# Altium schematic sheet Carambola2-Base.SchDoc
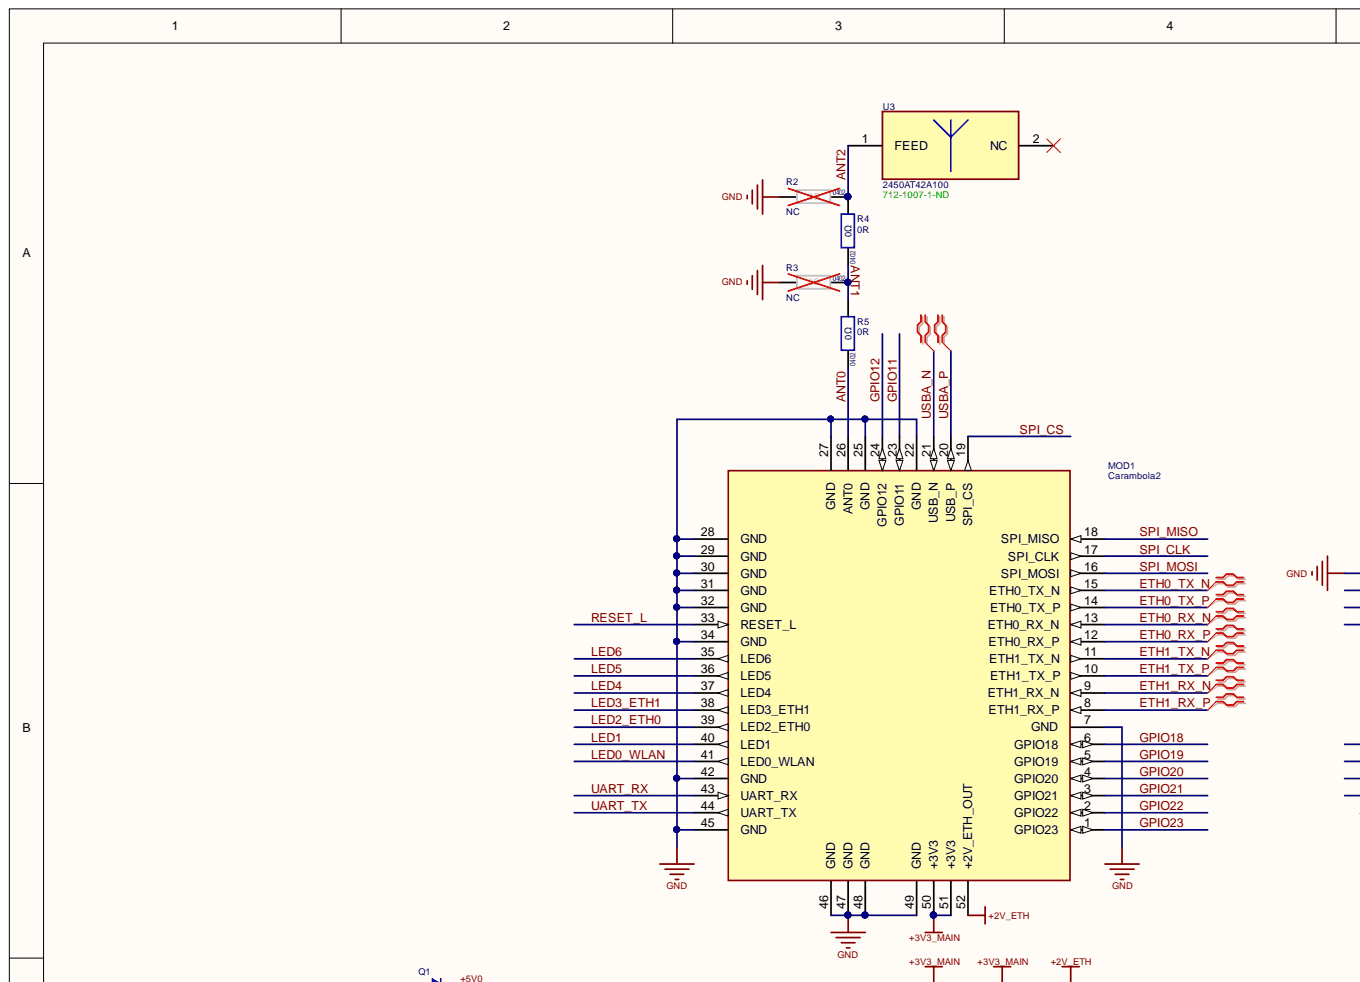
[diagram p0: Carambola2-Base.SchDoc, top left]
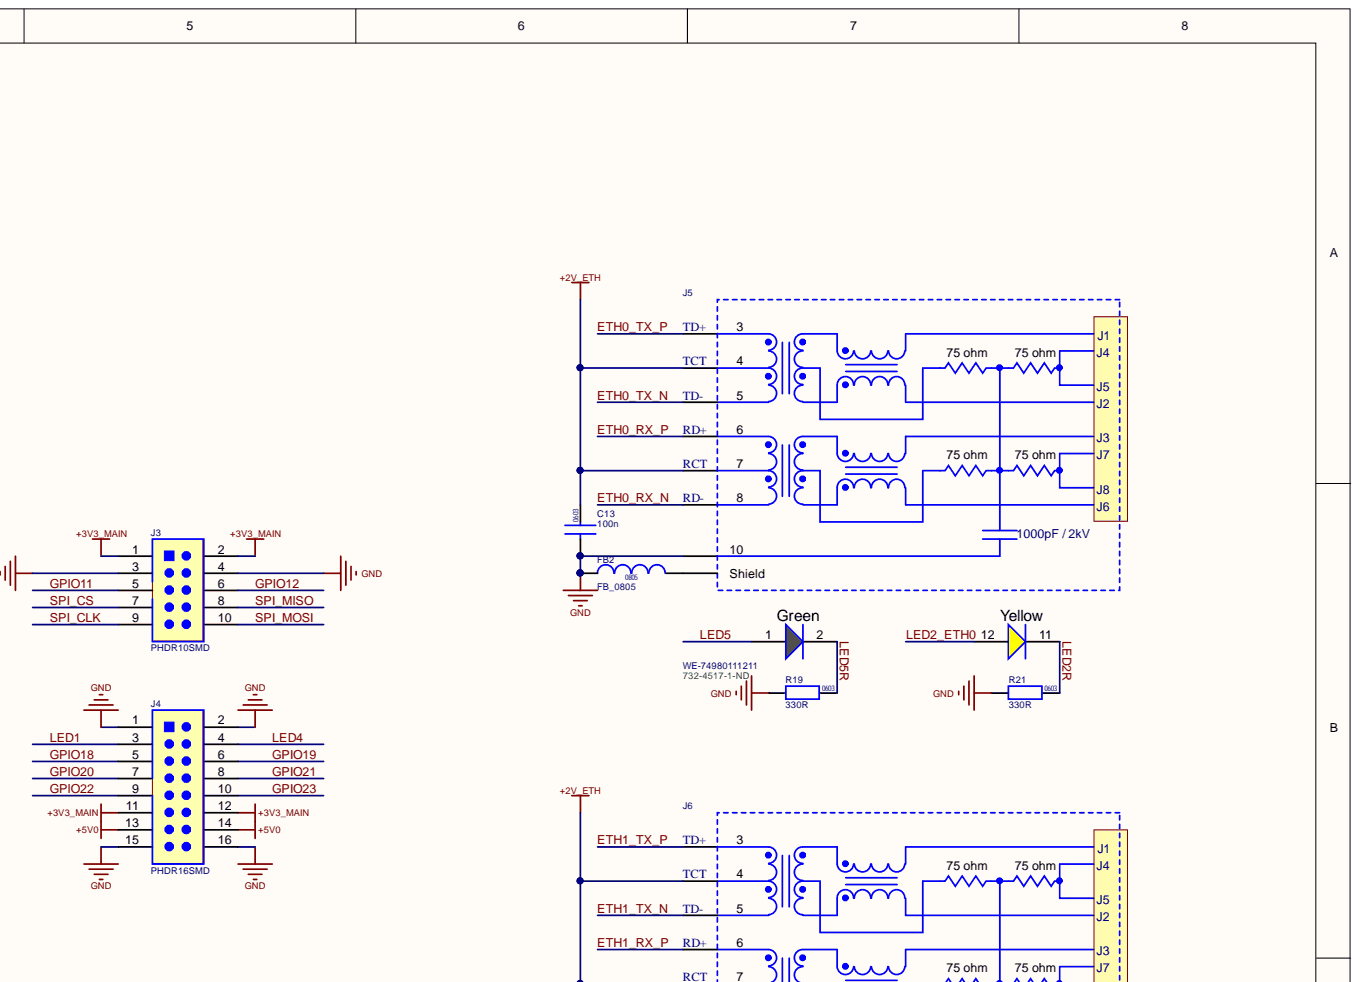
[diagram p1: Carambola2-Base.SchDoc, top right]
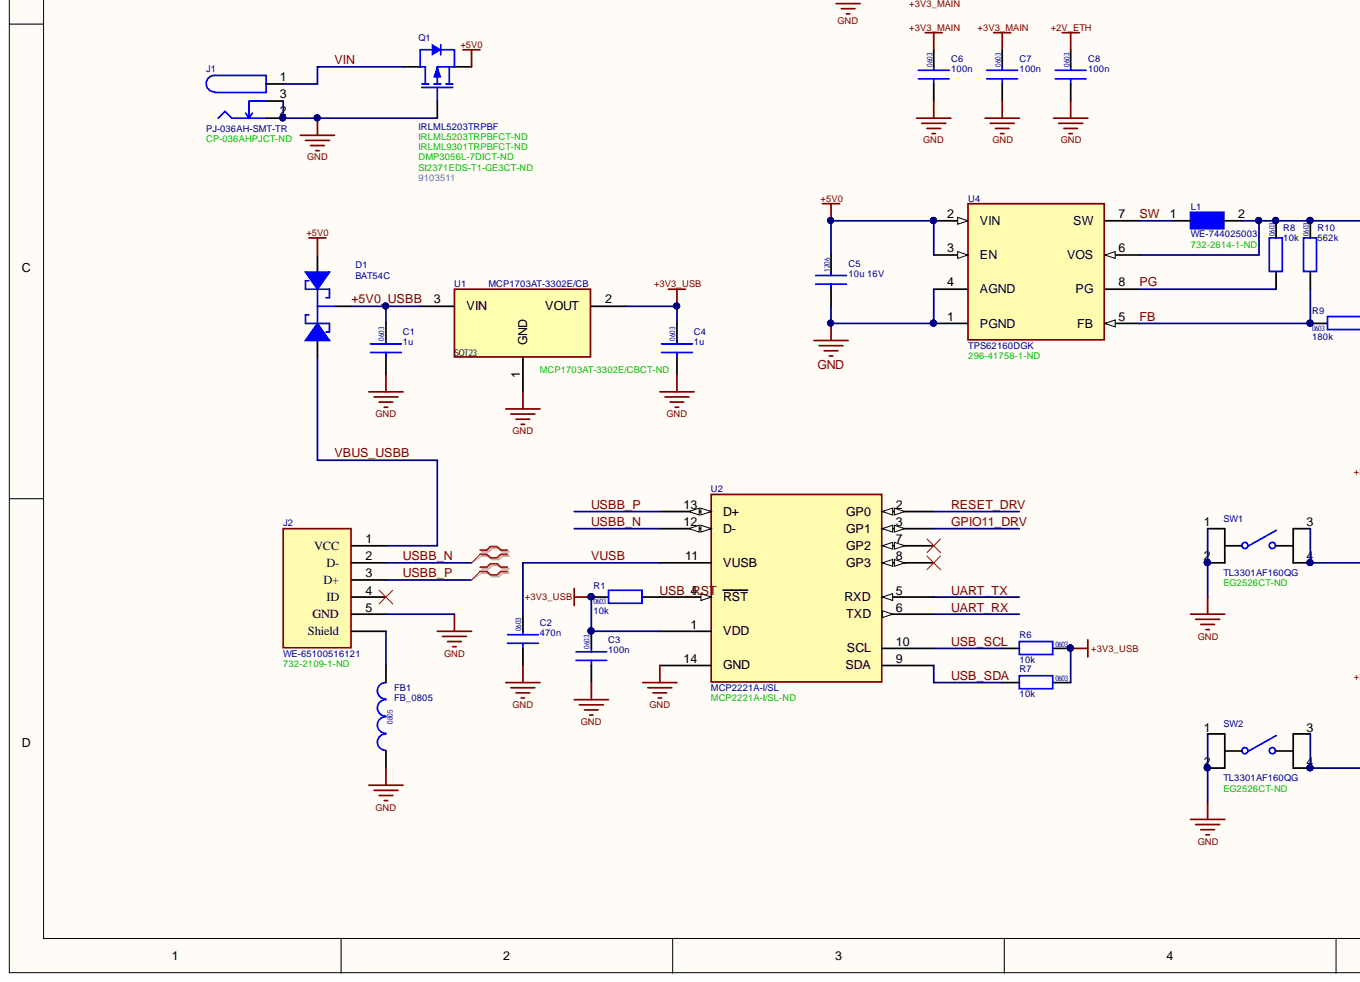
[diagram p2: Carambola2-Base.SchDoc, bottom left]
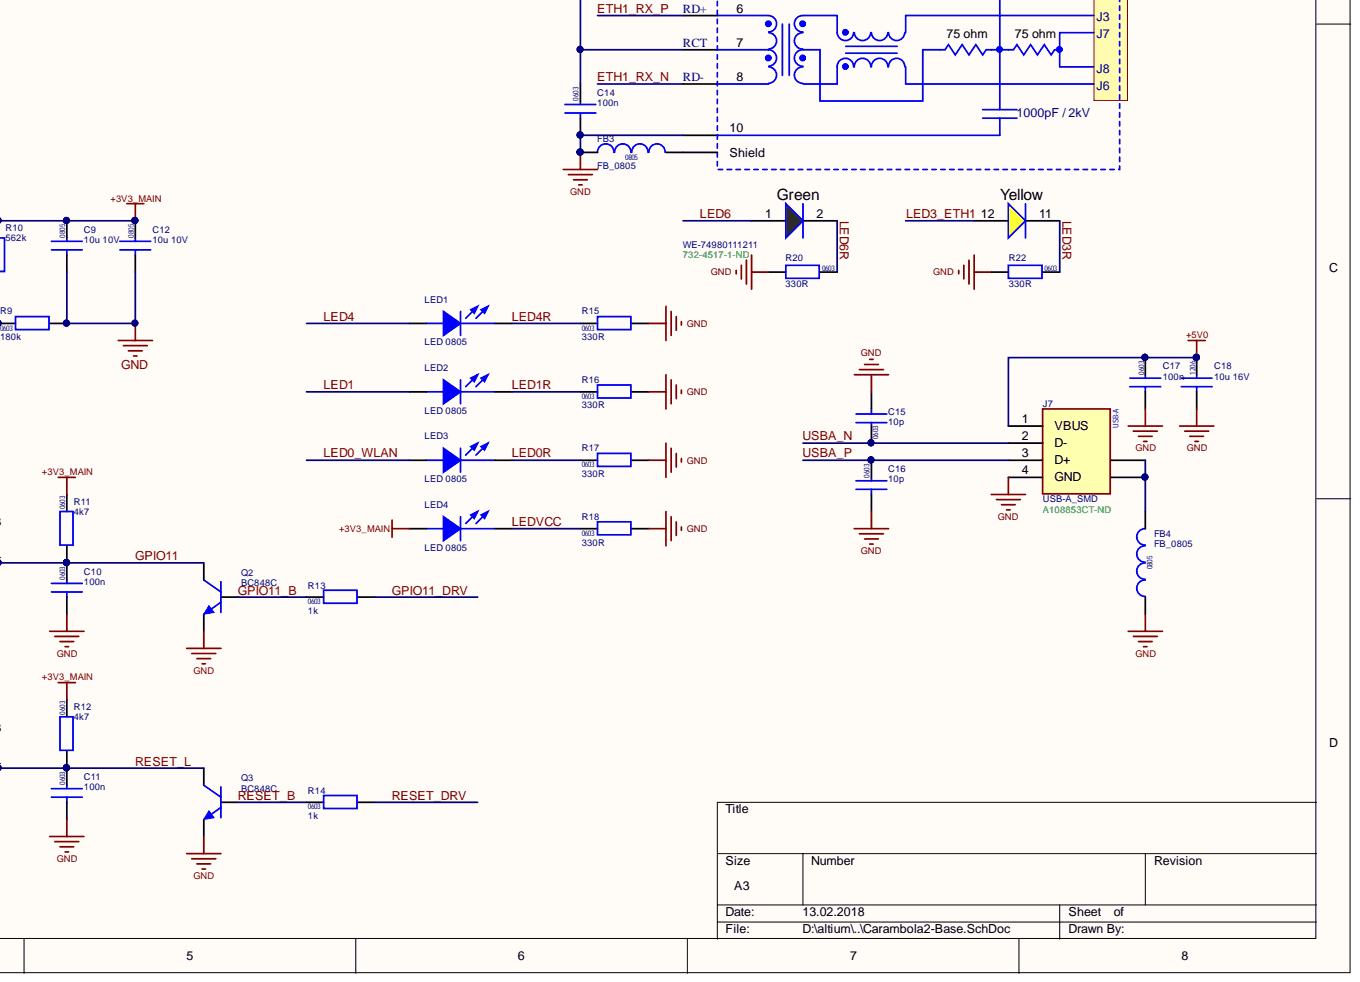
[diagram p3: Carambola2-Base.SchDoc, bottom right]

## Parts
C1  1u  [CAPC0603]
C2  470n  [CAPC0603]
C3  100n  [CAPC0603]
C4  1u  [CAPC0603]
C5  10u 16V  [CAPC1206]
C6  100n  [CAPC0603]
C7  100n  [CAPC0603]
C8  100n  [CAPC0603]
C9  10u 10V  [CAPC0805]
C10  100n  [CAPC0603]
C11  100n  [CAPC0603]
C12  10u 10V  [CAPC0805]
C13  100n  [CAPC0603]
C14  100n  [CAPC0603]
C15  10p  [CAPC0603]
C16  10p  [CAPC0603]
C17  100n  [CAPC0603]
C18  10u 16V  [CAPC1206]
D1  BAT54C  [SOT23-3]
FB1  FB_0805  [FB_0805]
FB2  FB_0805  [FB_0805]
FB3  FB_0805  [FB_0805]
FB4  FB_0805  [FB_0805]
J1  PJ-036AH-SMT-TR  Supplier Part Number 1: CP-036AHPJCT-ND  [PJ-036AH-SMT-TR]
J2  WE-65100516121  Supplier Part Number 1: 732-2109-1-ND  [65100516121]
J3  PHDR10SMD  [PHDR10SMD]
J4  PHDR16SMD  [PHDR16SMD]
J5  WE-74980111211  Supplier Part Number 1: 732-4517-1-ND  [74980111211]
J6  WE-74980111211  Supplier Part Number 1: 732-4517-1-ND  [74980111211]
J7  USB-A_SMD  Supplier Part Number 1: A108853CT-ND  [USB-A_SMD]
L1  WE-744025003  Supplier Part Number 1: 732-2614-1-ND  [WE-TPC_2828]
LED1  LED 0805  [LED 0805]
LED2  LED 0805  [LED 0805]
LED3  LED 0805  [LED 0805]
LED4  LED 0805  [LED 0805]
MOD1  Carambola2  [8DEV_0301_0002_28X38_FP]
Q1  IRLML5203TRPBF  Supplier Part Number 1: IRLML5203TRPBFCT-ND  Supplier Part Number 2: IRLML9301TRPBFCT-ND  Supplier Part Number 3: DMP3056L-7DICT-ND  [SOT23-3]
Q2  BC848C  [SOT23-3]
Q3  BC848C  [SOT23-3]
R1  10k  [RESC0603]
R2  NC  [RESC0402_0R_NC]  (no BOM)
R3  NC  [RESC0402_0R_NC]  (no BOM)
R4  0R  [RESC0402_0R]
R5  0R  [RESC0402_0R]
R6  10k  [RESC0603]
R7  10k  [RESC0603]
R8  10k  [RESC0603]
R9  180k  [RESC0603]
R10  562k  [RESC0603]
R11  4k7  [RESC0603]
R12  4k7  [RESC0603]
R13  1k  [RESC0603]
R14  1k  [RESC0603]
R15  330R  [RESC0603]
R16  330R  [RESC0603]
R17  330R  [RESC0603]
R18  330R  [RESC0603]
R19  330R  [RESC0603]
R20  330R  [RESC0603]
R21  330R  [RESC0603]
R22  330R  [RESC0603]
SW1  TL3301AF160QG  Supplier Part Number 1: EG2526CT-ND  [TL3301AF160QG]
SW2  TL3301AF160QG  Supplier Part Number 1: EG2526CT-ND  [TL3301AF160QG]
U1  MCP1703AT-3302E/CB  Supplier Part Number 1: MCP1703AT-3302E/CBCT-ND  [SOT23-3]
U2  MCP2221A-I/SL  Supplier Part Number 1: MCP2221A-I/SL-ND  [SOIC14N]
U3  2450AT42A100  Supplier Part Number 1: 712-1007-1-ND  [CHIP_ANTENNA_2.4GHZ_JOHANSON]
U4  TPS62160DGK  Supplier Part Number 1: 296-41758-1-ND  [TI-DGK-S-PDSO-G8]

## Nets
+2V_ETH: C8.1 C13.1 C14.1 J5.4 J5.7 J6.4 J6.7 MOD1.52(+2V_ETH_OUT)
+3V3_MAIN: C6.1 C7.1 C9.1 C12.1 J3.1 J3.2 J4.11 J4.12 L1.2 LED4.1 MOD1.50(+3V3) MOD1.51(+3V3) R8.2 R10.2 R11.2 R12.2 U4.6(VOS)
+3V3_USB: C3.1 C4.1 R1.2 R6.2 R7.2 U1.2 U2.1(VDD)
+5V0: C5.1 C17.1 C18.1 D1.1 J4.13 J4.14 J7.1(VBUS) Q1.2 U4.2(VIN) U4.3(EN)
GND: C1.2 C2.2 C3.2 C4.2 C5.2 C6.2 C7.2 C8.2 C9.2 C10.2 C11.2 C12.2 C13.2 C14.2 C15.2 C16.2 C17.2 C18.2 FB1.1 FB2.1 FB3.1 FB4.1 J1.2 J1.3 J2.5(GND) J3.3 J3.4 J4.1 J4.2 J4.15 J4.16 J5.10 J6.10 J7.4(GND) MOD1.7(GND) MOD1.22(GND) MOD1.25(GND) MOD1.27(GND) MOD1.28(GND) MOD1.29(GND) MOD1.30(GND) MOD1.31(GND) MOD1.32(GND) MOD1.34(GND) MOD1.42(GND) MOD1.45(GND) MOD1.46(GND) MOD1.47(GND) MOD1.48(GND) MOD1.49(GND) Q1.1 Q2.2 Q3.2 R2.1 R3.1 R9.1 R15.1 R16.1 R17.1 R18.1 R19.1 R20.1 R21.1 R22.1 SW1.1 SW1.2 SW2.1 SW2.2 U1.1 U2.14(GND) U4.1(PGND) U4.4(AGND)
+5V0_USBB: C1.1 D1.3 U1.3
ANT0: MOD1.26(ANT0) R5.2
ANT1: R3.2 R4.2 R5.1
ANT2: R2.2 R4.1 U3.1
ETH0_RX_N: J5.8 MOD1.13(ETH0_RX_N)
ETH0_RX_P: J5.6 MOD1.12(ETH0_RX_P)
ETH0_TX_N: J5.5 MOD1.15(ETH0_TX_N)
ETH0_TX_P: J5.3 MOD1.14(ETH0_TX_P)
ETH1_RX_N: J6.8 MOD1.9(ETH1_RX_N)
ETH1_RX_P: J6.6 MOD1.8(ETH1_RX_P)
ETH1_TX_N: J6.5 MOD1.11(ETH1_TX_N)
ETH1_TX_P: J6.3 MOD1.10(ETH1_TX_P)
FB: R9.2 R10.1 U4.5(FB)
GPIO11: C10.1 J3.5 MOD1.23(GPIO11) Q2.3 R11.1 SW1.3 SW1.4
GPIO11_B: Q2.1 R13.2
GPIO11_DRV: R13.1 U2.3(GP1)
GPIO12: J3.6 MOD1.24(GPIO12)
GPIO18: J4.5 MOD1.6(GPIO18)
GPIO19: J4.6 MOD1.5(GPIO19)
GPIO20: J4.7 MOD1.4(GPIO20)
GPIO21: J4.8 MOD1.3(GPIO21)
GPIO22: J4.9 MOD1.2(GPIO22)
GPIO23: J4.10 MOD1.1(GPIO23)
LED0_WLAN: LED3.1 MOD1.41(LED0_WLAN)
LED0R: LED3.2 R17.2
LED1: J4.3 LED2.1 MOD1.40(LED1)
LED1R: LED2.2 R16.2
LED2_ETH0: J5.12 MOD1.39(LED2_ETH0)
LED2R: J5.11 R21.2
LED3_ETH1: J6.12 MOD1.38(LED3_ETH1)
LED3R: J6.11 R22.2
LED4: J4.4 LED1.1 MOD1.37(LED4)
LED4R: LED1.2 R15.2
LED5: J5.1 MOD1.36(LED5)
LED5R: J5.2 R19.2
LED6: J6.1 MOD1.35(LED6)
LED6R: J6.2 R20.2
LEDVCC: LED4.2 R18.2
PG: R8.1 U4.8(PG)
RESET_B: Q3.1 R14.2
RESET_DRV: R14.1 U2.2(GP0)
RESET_L: C11.1 MOD1.33(RESET_L) Q3.3 R12.1 SW2.3 SW2.4
SPI_CLK: J3.9 MOD1.17(SPI_CLK)
SPI_CS: J3.7 MOD1.19(SPI_CS)
SPI_MISO: J3.8 MOD1.18(SPI_MISO)
SPI_MOSI: J3.10 MOD1.16(SPI_MOSI)
SW: L1.1 U4.7(SW)
UART_RX: MOD1.43(UART_RX) U2.6(TXD)
UART_TX: MOD1.44(UART_TX) U2.5(RXD)
USB_RST: R1.1 U2.4(RST)
USB_SCL: R6.1 U2.10(SCL)
USB_SDA: R7.1 U2.9(SDA)
USBA_N: C15.1 J7.2(D-) MOD1.21(USB_N)
USBA_P: C16.1 J7.3(D+) MOD1.20(USB_P)
USBB_N: J2.2(D-) U2.12(D-)
USBB_P: J2.3(D+) U2.13(D+)
VBUS_USBB: D1.2 J2.1(VCC)
VIN: J1.1 Q1.3
VUSB: C2.1 U2.11(VUSB)
NetFB1_2: FB1.2 J2.Shell(Shield)
NetFB2_2: FB2.2 J5.Shield
NetFB3_2: FB3.2 J6.Shield
NetFB4_2: FB4.2 J7.5 J7.6

Not connected: J2 4(ID); U2 7(GP2) 8(GP3); U3 2

## Library data of the parts
C1-C4 C6-C8 C10 C11 C13-C17 = CAP 0603: MLCC Ceramic Capacitor; Component Kind: Standard; Library Name: Standard.SchLib
C5 C18 = CAP 1206: MLCC Ceramic Capacitor; Component Kind: Standard; Library Name: Standard.SchLib
C9 C12 = CAP 0805: MLCC Ceramic Capacitor; Component Kind: Standard; Library Name: Standard.SchLib
D1 = BAT54C: BAT54C SOT-23 Plastic-Encapsulated Diode; Component Kind: Standard; Library Name: Standard.SchLib
FB1-FB4 = FB_0805: Ferrite Bead SMD; Component Kind: Standard; Library Name: Standard.SchLib
J1 = PJ-036AH-SMT-TR: CONN PWR JACK 2X5.5MM SOLDER; Component Kind: Standard; Supplier 1: Digi-Key; Library Name: Carambola2.SchLib
J2 = WE-65100516121: Mini USB 2.0 Type B SMT Horizontal 5 Contacts WR-COM; Gender: Receptacle; Interface Type: Type B; Component Kind: Standard; ComponentLink1Description: Manufacturer; ComponentLink1URL: http://www.we-online.de; ComponentLink2Description: Datasheet; ComponentLink2URL: http://katalog.we-online.de/em/datasheet/65100516121.pdf; Construction: SMT; Part Number: 65100516121; Published Date: April 2017; Sub-Family: Mini USB 2.0; Supplier 1: Digi-Key; Type: Horizontal; Library Name: Carambola2.SchLib; Publisher: Wurth Elektronik
J3 = PHDR10SMD: Shrouded Pin Header SMD Vertical 10 pins 2.54mm; Component Kind: Standard; Library Name: PinHeaders.SchLib
J4 = PHDR16SMD: Shrouded Pin Header SMD Vertical 16 pins 2.54mm; Component Kind: Standard; Library Name: PinHeaders.SchLib
J5 J6 = WE-74980111211: WE-RJ45 LAN Transformer, 10/100 Base-T; Number of Ports: 1x1; PoE: non-PoE; USB: No; Component Kind: Standard; ComponentLink1Description: Manufacturer; ComponentLink1URL: http://www.we-online.de; ComponentLink2Description: Datasheet; ComponentLink2URL: http://katalog.we-online.de/pbs/datasheet/74980111211.pdf; Data Rate: 10/100 Base-T; Part Number: 74980111211; Published Date: Jan. 2017; Supplier 1: Digi-Key; Library Name: Carambola2.SchLib; Publisher: Wurth Elektronik
J7 = USB-A_SMD: USB 1.1, Right Angle, SMD, A Type, Receptacle, 4 Position; Component Kind: Standard; Library Name: connectors.SchLib; Supplier 1: Digi-Key
L1 = WE-TPC_2828_744025003: Shielded Tiny Power Inductor WE-TPC; Inductance: 3.3u; MFG: WUERTH; Component Kind: Standard; Supplier 1: Digi-Key; Library Name: Carambola2.SchLib
MOD1 = Carambola2: Carambola2 Modul; Component Kind: Standard; Library Name: Carambola2.SchLib
Q1 = IRLML5203TRPBF: P-Channel MOSFET; Component Kind: Standard; Library Name: discretes.SchLib; Supplier 1: Digi-Key; Supplier 2: Digi-Key; Supplier 3: Digi-Key; Supplier 4: Digi-Key; Supplier 5: Farnell; Supplier Part Number 4: SI2371EDS-T1-GE3CT-ND; Supplier Part Number 5: 9103511
Q2 Q3 = BC848C: General Purpose Transistor, NPN Silicon, 3-Pin SOT-23; Component Kind: Standard; Library Name: discretes.SchLib
R1 R6-R22 = R 0603: Chip Resistor; Component Kind: Standard; Library Name: Standard.SchLib
R2 R3 = 0R_NC 0402: Chip Resistor or Solder Jumper, not fitted; Library Name: Standard.SchLib
R4 R5 = 0R 0402: Chip Resistor or Solder Jumper, fitted; Component Kind: Standard; Library Name: Standard.SchLib
SW1 SW2 = TL3301AF160QG: Single-Pole, Single-Throw Switch; Component Kind: Standard; Supplier 1: Digi-Key; Library Name: Carambola2.SchLib
U1 = MCP1703AT-3302E/CB: 250 mA, 16V, Low Quiescent Current LDO Regulator; Component Kind: Standard; Supplier 1: Digi-Key; Library Name: Carambola2.SchLib
U2 = MCP2221A-I/SL: USB-UART Bridge; Component Kind: Standard; Library Name: digital.SchLib; Supplier 1: Digi-Key
U3 = CHIP_ANTENNA_2.4GHZ_JOHANSON: Johanson 2.45 GHz Chip Antenna; Component Kind: Standard; Supplier 1: Digi-Key; Library Name: Carambola2.SchLib
U4 = TPS62160DGK: 3V-17V 1A Step-Down Converters with DCS-Control; Component Kind: Standard; Supplier 1: Digi-Key; Library Name: Carambola2.SchLib
LED1-LED4 = LED 0805: Component Kind: Standard; Description: LED 0805; Library Name: Standard.SchLib
